# Altium schematic sheet TFT LCD ER-TFT018-2.SchDoc
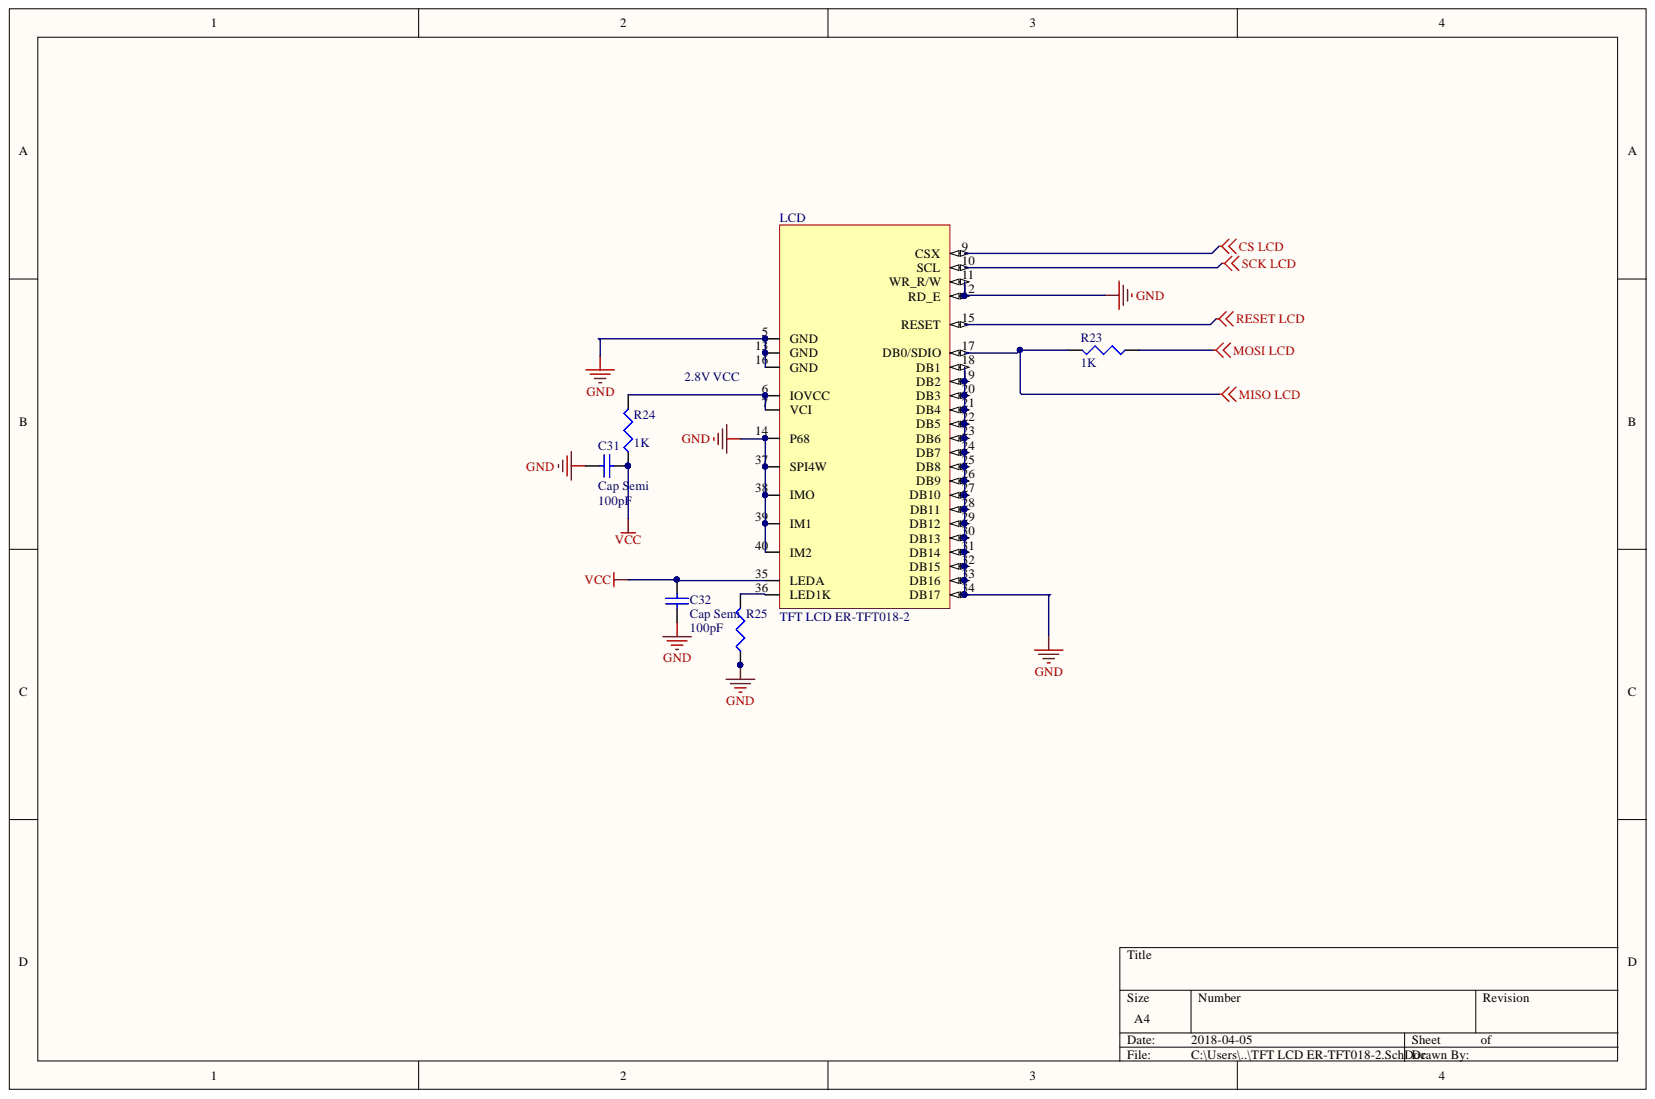
[diagram p0: TFT LCD ER-TFT018-2.SchDoc]

## Parts
C31  Cap Semi  value 100pF  [C1206]
C32  Cap Semi  value 100pF  [C1206]
LCD  TFT LCD ER-TFT018-2  [LCD TFT Display]
R23  value 1K  [J1-0603]  (hidden comment: Res3)
R24  value 1K  [J1-0603]  (hidden comment: Res3)
R25  Res3  [J1-0603]  (value not drawn)

## Nets
CS LCD: LCD.9(CSX)
GND: C31.1 C32.1 LCD.5(GND) LCD.11(WR_R/W) LCD.12(RD_E) LCD.13(GND) LCD.14(P68) LCD.16(GND) LCD.18(DB1) LCD.19(DB2) LCD.20(DB3) LCD.21(DB4) LCD.22(DB5) LCD.23(DB6) LCD.24(DB7) LCD.25(DB8) LCD.26(DB9) LCD.27(DB10) LCD.28(DB11) LCD.29(DB12) LCD.30(DB13) LCD.31(DB14) LCD.32(DB15) LCD.33(DB16) LCD.34(DB17) LCD.37(SPI4W) LCD.38(IMO) LCD.39(IM1) LCD.40(IM2) R25.1
MISO LCD: LCD.17(DB0/SDIO) R23.2
MOSI LCD: R23.1
RESET LCD: LCD.15(RESET)
SCK LCD: LCD.10(SCL)
VCC: C31.2 C32.2 LCD.35(LEDA) R24.1
NetLCD_6: LCD.6(IOVCC) LCD.7(VCI) R24.2
NetLCD_36: LCD.36(LED1K) R25.2

## Library data of the parts
C31 C32 = Cap Semi: Capacitor (Semiconductor SIM Model); Component Kind: Standard; LatestRevisionDate: 29-May-2009; LatestRevisionNote: IPC-7351 Footprint Added.; Library Name: Miscellaneous Devices.IntLib; PackageDescription: Chip Capacitor; PackageReference: C1206; Published: 8-Jun-2000; Signal Integrity: Cap Semi; Simulation: CAP; Publisher: Altium Limited
R23 R24 = Res3: Resistor; Component Kind: Standard; LatestRevisionDate: 29-May-2009; LatestRevisionNote: IPC-7351 Footprint Added.; Library Name: Miscellaneous Devices.IntLib; PackageDescription: Chip Resistor; PackageReference: J1-0603; Published: 8-Jun-2000; Signal Integrity: Res; Simulation: RESISTOR; Publisher: Altium Limited
R25 = Res3: Resistor; Value: 1K; Component Kind: Standard; LatestRevisionDate: 29-May-2009; LatestRevisionNote: IPC-7351 Footprint Added.; Library Name: Miscellaneous Devices.IntLib; PackageDescription: Chip Resistor; PackageReference: J1-0603; Published: 8-Jun-2000; Signal Integrity: Res; Simulation: RESISTOR; Publisher: Altium Limited
LCD = TFT LCD ER-TFT018-2: Component Kind: Standard; Library Name: HGCDLibrary.IntLib
